# Altium schematic sheet controller.SchDoc(controller)
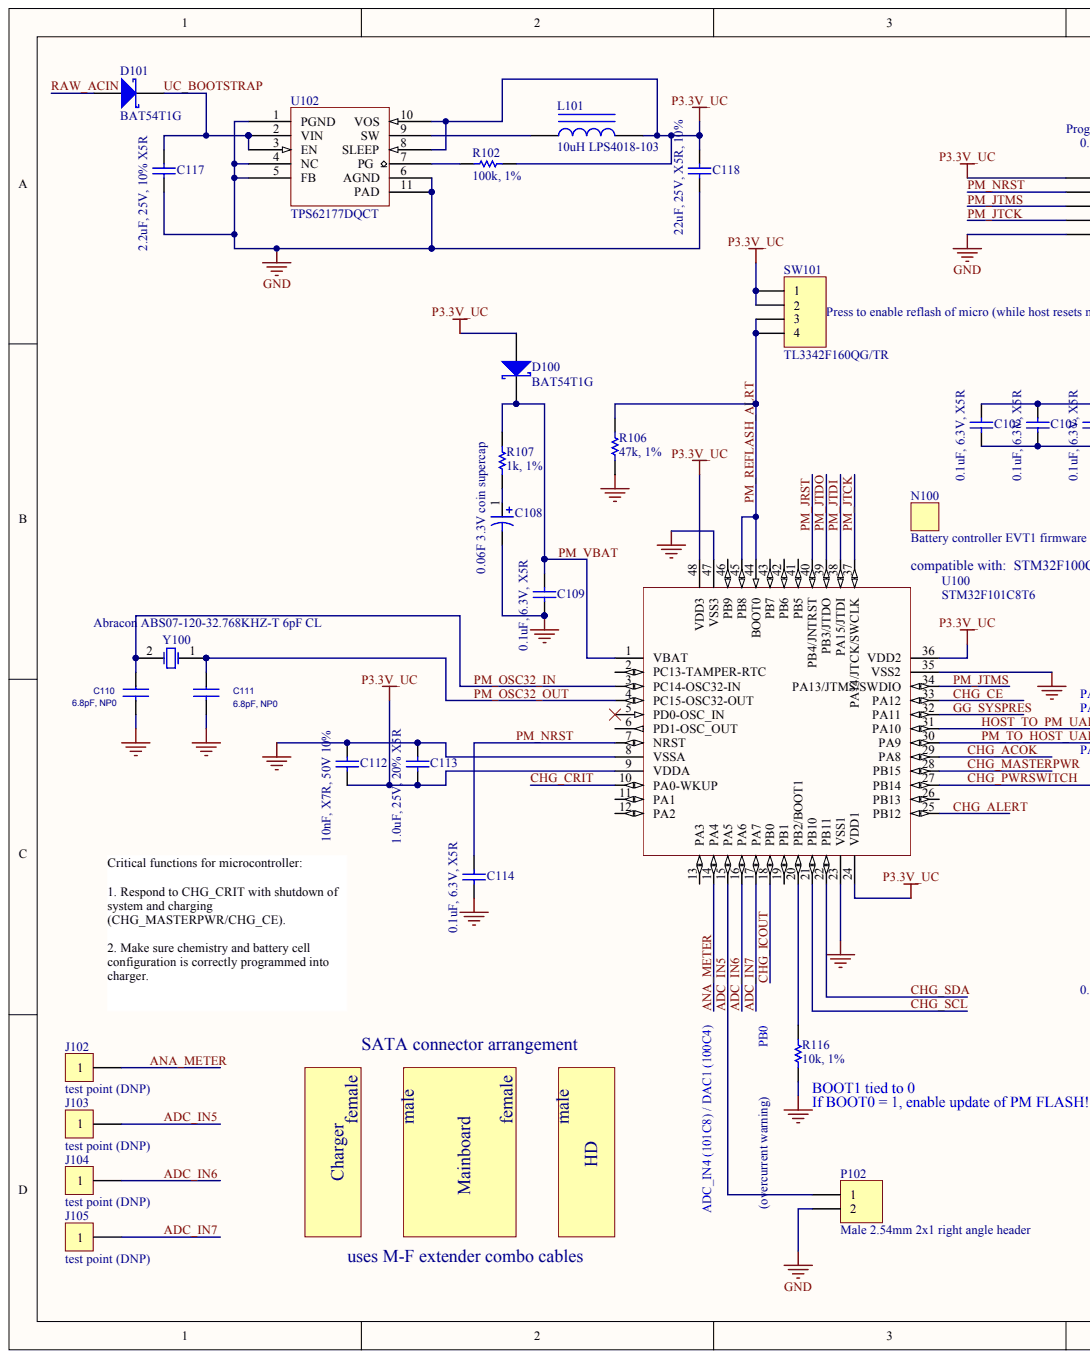
[diagram p0: controller.SchDoc, left]
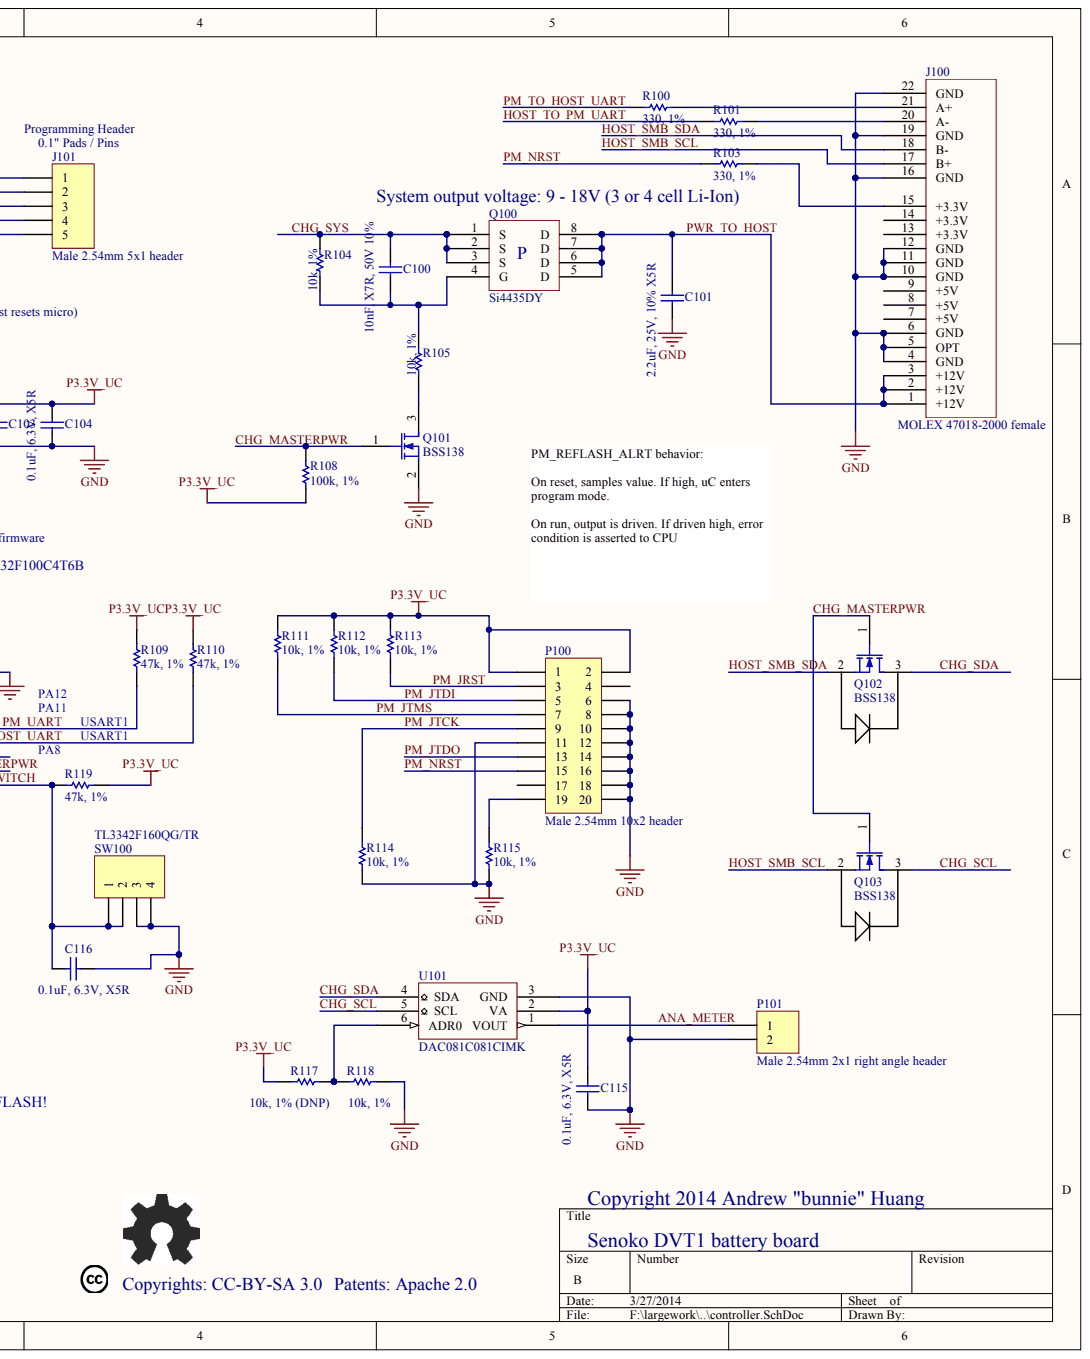
[diagram p1: controller.SchDoc, right]

## Parts
C100  10nF, X7R, 50V 10%
C101  2.2uF, 25V, 10% X5R
C102  0.1uF, 6.3V, X5R
C103  0.1uF, 6.3V, X5R
C104  0.1uF, 6.3V, X5R
C108  0.06F 3.3V coin supercap
C109  0.1uF, 6.3V, X5R
C110  6.8pF, NP0
C111  6.8pF, NP0
C112  10nF, X7R, 50V 10%
C113  1.0uF, 25V, 20% X5R
C114  0.1uF, 6.3V, X5R
C115  0.1uF, 6.3V, X5R
C116  0.1uF, 6.3V, X5R
C117  2.2uF, 25V, 10% X5R
C118  22uF, 25V, X5R, 10%
D100  BAT54T1G
D101  BAT54T1G
J100  MOLEX 47018-2000 female
J101  Male 2.54mm 5x1 header
J102  test point (DNP)
J103  test point (DNP)
J104  test point (DNP)
J105  test point (DNP)
L101  10uH LPS4018-103
N100  Battery controller EVT1 firmware
P100  Male 2.54mm 10x2 header
P101  Male 2.54mm 2x1 right angle header
P102  Male 2.54mm 2x1 right angle header
Q100  Si4435DY
Q101  BSS138
Q102  BSS138
Q103  BSS138
R100  330, 1%
R101  330, 1%
R102  100k, 1%
R103  330, 1%
R104  10k, 1%
R105  10k, 1%
R106  47k, 1%
R107  1k, 1%
R108  100k, 1%
R109  47k, 1%
R110  47k, 1%
R111  10k, 1%
R112  10k, 1%
R113  10k, 1%
R114  10k, 1%
R115  10k, 1%
R116  10k, 1%
R117  10k, 1% (DNP)
R118  10k, 1%
R119  47k, 1%
SW100  TL3342F160QG/TR
SW101  TL3342F160QG/TR
U100  STM32F101C8T6
U101  DAC081C081CIMK
U102  TPS62177DQCT
Y100  Abracon ABS07-120-32.768KHZ-T 6pF CL

## Nets
GND: C101.2 C102.2 C103.2 C104.2 C108.2 C109.2 C110.2 C111.2 C112.1 C113.1 C114.2 C115.2 C116.1 C117.2 C118.2 J100.4(GND) J100.5(OPT) J100.6(GND) J100.10(GND) J100.11(GND) J100.12(GND) J100.16(GND) J100.19(GND) J100.22(GND) J101.5 P100.6 P100.8 P100.10 P100.11 P100.12 P100.14 P100.16 P100.18 P100.20 P101.2 P102.2 Q101.2 R106.1 R114.1 R115.1 R116.1 R118.2 SW100.3 SW100.4 U100.8(VSSA) U100.23(VSS1) U100.35(VSS2) U100.47(VSS3) U101.3(GND) U102.1(PGND) U102.4(NC) U102.5(FB) U102.6(AGND) U102.11(PAD)
P3.3V_UC: C102.1 C103.1 C104.1 C112.2 C113.2 C115.1 C118.1 D100.1 J101.1 L101.2 P100.1 P100.2 R102.1 R108.1 R109.2 R110.2 R111.2 R112.2 R113.2 R117.1 R119.2 SW101.1 SW101.2 U100.9(VDDA) U100.24(VDD1) U100.36(VDD2) U100.48(VDD3) U101.2(VA) U102.8(SLEEP) U102.10(VOS)
ADC_IN5: J103.1 P102.1 U100.15(PA5)
ADC_IN6: J104.1 U100.16(PA6)
ADC_IN7: J105.1 U100.17(PA7)
ANA_METER: J102.1 P101.1 U100.14(PA4) U101.1(VOUT)
CHG_ACOK: U100.29(PA8)
CHG_ALERT: U100.25(PB12)
CHG_CE: U100.33(PA12)
CHG_CRIT: U100.10(PA0-WKUP)
CHG_ICOUT: U100.18(PB0)
CHG_MASTERPWR: Q101.1 Q102.1 Q103.1 R108.2 U100.28(PB15)
CHG_PWRSWITCH: C116.2 R119.1 SW100.1 SW100.2 U100.27(PB14)
CHG_SCL: Q103.3 U100.21(PB10) U101.5(SCL)
CHG_SDA: Q102.3 U100.22(PB11) U101.4(SDA)
CHG_SYS: C100.1 Q100.1(S) Q100.2(S) Q100.3(S) R104.2
GG_SYSPRES: U100.32(PA11)
HOST_SMB_SCL: J100.17(B+) Q103.2
HOST_SMB_SDA: J100.18(B-) Q102.2
HOST_TO_PM_UART: R101.1 R109.1 U100.31(PA10)
PM_JRST: P100.3 R113.1 U100.40(PB4/JNTRST)
PM_JTCK: J101.4 P100.9 R114.2 U100.37(PA14/JTCK/SWCLK)
PM_JTDI: P100.5 R112.1 U100.38(PA15/JTDI)
PM_JTDO: P100.13 U100.39(PB3/JTDO)
PM_JTMS: J101.3 P100.7 R111.1 U100.34(PA13/JTMS/SWDIO)
PM_NRST: C114.1 J101.2 P100.15 R103.1 U100.7(NRST)
PM_OSC32_IN: C110.1 U100.3(PC14-OSC32-IN) Y100.2
PM_OSC32_OUT: C111.1 U100.4(PC15-OSC32-OUT) Y100.1
PM_REFLASH_ALRT: R106.2 SW101.3 SW101.4 U100.44(BOOT0) U100.45(PB8)
PM_TO_HOST_UART: R100.1 R110.1 U100.30(PA9)
PM_VBAT: C109.1 D100.2 R107.2 U100.1(VBAT)
PWR_TO_HOST: C101.1 J100.1(+12V) J100.2(+12V) J100.3(+12V) Q100.5(D) Q100.6(D) Q100.7(D) Q100.8(D)
RAW_ACIN: D101.1
UC_BOOTSTRAP: C117.1 D101.2 U102.2(VIN) U102.3(EN)
NetC100_2: C100.2 Q100.4(G) R104.1 R105.2
NetC108_1: C108.1 R107.1
NetJ100_15: J100.15(+3.3V) R103.2
NetJ100_20: J100.20(A-) R101.2
NetJ100_21: J100.21(A+) R100.2
NetL101_1: L101.1 U102.9(SW)
NetP100_19: P100.19 R115.2
NetQ101_3: Q101.3 R105.1
NetR102_2: R102.2 U102.7(PG)
NetR116_2: R116.2 U100.20(PB2/BOOT1)
NetR117_2: R117.2 R118.1 U101.6(ADR0)

Not connected: J100 7(+5V) 8(+5V) 9(+5V) 13(+3.3V) 14(+3.3V); P100 4 17; U100 2(PC13-TAMPER-RTC) 5(PD0-OSC_IN) 6(PD1-OSC_OUT) 11(PA1) 12(PA2) 13(PA3) 19(PB1) 26(PB13) 41(PB5) 42(PB6) 43(PB7) 46(PB9)

## Library data of the parts
C110 C111 = (no symbol name): Right: 20; Bottom: 10
D100 D101 = (no symbol name): Code - JEDEC: DO-214-AA; Published: 8-Jun-2000; Revision: July-2002: Re-released for DXP Platform.; Publisher: Altium Limited
R100 R101 R103-R107 R109-R119 = (no symbol name): Value: 100k; Published: 8-Jun-2000; LatestRevisionDate: 17-Jul-2002; LatestRevisionNote: Re-released for DXP Platform.; PackageReference: AXIAL-0.3; Publisher: Altium Limited
C100-C104 C108 C109 C112-C118 J100-J105 L101 N100 P100-P102 Q100-Q103 R102 R108 SW100 SW101 U100-U102 Y100 = (no symbol name)
  C100-C104 C109 C112-C118: Published: 8-Jun-2000; LatestRevisionDate: 17-Jul-2002; LatestRevisionNote: Re-released for DXP Platform.; PackageReference: C1206
  C108: Published: 8-Jun-2000; LatestRevisionDate: 17-Jul-2002; LatestRevisionNote: Re-released for DXP Platform.; PackageReference: C0805
  J101 P100-P102 SW100 SW101: LatestRevisionDate: 17-Jul-2002; LatestRevisionNote: Re-released for DXP Platform.
  L101: Published: 24-Mar-1999; LatestRevisionDate: 17-Jul-2002; LatestRevisionNote: Re-released for DXP Platform.; PackageReference: AXIAL-0.9
  Q101-Q103: Published: 8-Jun-2000; LatestRevisionDate: 17-Jul-2002; LatestRevisionNote: Re-released for DXP Platform.; PackageVersion: 1995/1996; PackageReference: E3
  R102 R108: Published: 8-Jun-2000; LatestRevisionDate: 17-Jul-2002; LatestRevisionNote: Re-released for DXP Platform.; PackageReference: AXIAL-0.3; Value: 100k
  Y100: Published: 8-Jun-2000; LatestRevisionDate: 17-Jul-2002; LatestRevisionNote: Re-released for DXP Platform.; PackageReference: R38
  C100-C104 C108 C109 C112-C118 J101 L101 P100-P102 Q101-Q103 R102 R108 SW100 SW101 Y100: Publisher: Altium Limited
